# Altium schematic sheet Sway Power Switch Rev B - Sheet 1.SchDoc
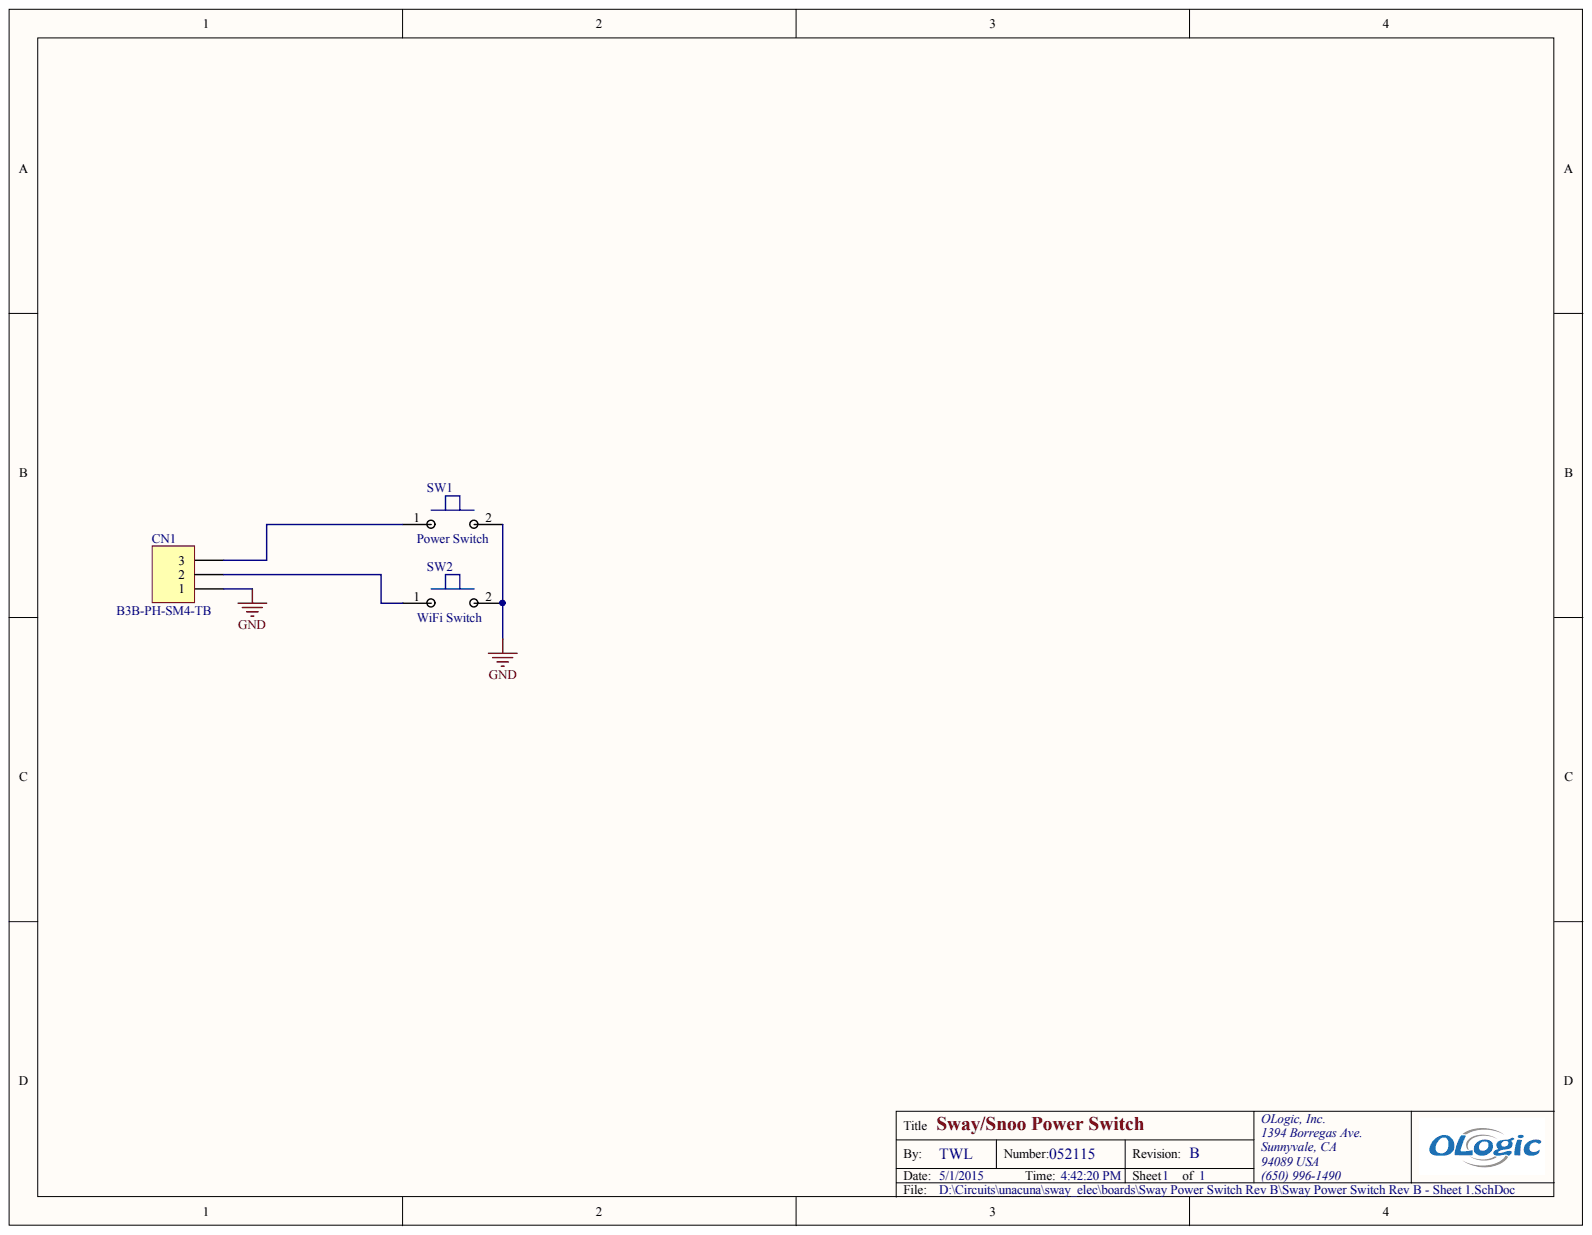
[diagram p0: Sway Power Switch Rev B - Sheet 1.SchDoc]

## Parts
CN1  B3B-PH-SM4-TB  [JST-B3B-PH-SM4-TB]
SW1  Power Switch  [TE-TactSwitch-3mmActuator]
SW2  WiFi Switch  [TE-TactSwitch-3mmActuator]

## Nets
GND: CN1.1 SW1.2 SW2.2
NetCN1_2: CN1.2 SW2.1
NetCN1_3: CN1.3 SW1.1

## Library data of the parts
CN1 = JST-B3B-PH-SM4-TB: CONN HEADER PH TOP 3POS 2MM SMD; Manufacturer: JST Sales America Inc; Manufacturer Part Number: B3B-PH-SM4-TB(LF)(SN)(P); Connector Type: Header, Shrouded; Number of Positions: 3; Number of Positions Loaded: All; Pitch: 0.079" (2.00mm); Category: Connectors, Interconnects; Color: Natural; Component Kind: Standard; ComponentLink1Description: http://www.jst-mfg.com/product/pdf/eng/ePH.pdf; ComponentLink1URL: http://www.jst-mfg.com/product/pdf/eng/ePH.pdf; Contact Finish: Tin; Contact Finish Thickness: -; Contact Mating Length: 0.130" (3.30mm); Contact Type: Male Pin; Fastening Type: Detent Lock; Features: Solder Retention; Library Name: OLogic-Connectors.IntLib; Mounting Type: Surface Mount; Number of Rows: 1; Packaging: Cut Tape (CT); Pricing 1: 1=0.6, 10=0.566, 25=0.5096, 50=0.4528, 100=0.434, 250=0.39628, 500=0.37742 (USD); RoHS: RoHS Compliant; Row Spacing: -; Stock 1: 32550; Supplier 1: Digi-Key; Supplier Part Number 1: 455-1735-1-ND; Termination: Solder
SW1 SW2 = SW-TE-FSM6JH: Reset Button; Manufacturer: TE Connectivity; Manufacturer Part Number: 1-1825910-0; Actuator Height off PCB, from Pins: 7.00mm; Actuator Material: Polyamide (PA66), Nylon 6/6; Actuator Orientation: Top Actuated; Actuator Type: Standard; Circuit: SPST-NO; Contact Rating @ Voltage: 0.05A @ 24VDC; Illumination: Non-Illuminated; Mechanical Life: 100,000 Cycles; Operating Force: 160gf; Outline: 6.00mm x 6.00mm; Switch Function: Off-Mom; Switch Travel: 0.25mm; Vendor: Digikey; Category: Switches; Component Kind: Standard; ComponentLink1Description: http://www.te.com/commerce/DocumentDelivery/DDEController?Action=srchrtrv&DocNm=1825910&DocType=Customer+Drawing&DocLang=English; ComponentLink1URL: http://www.te.com/commerce/DocumentDelivery/DDEController?Action=srchrtrv&DocNm=1825910&DocType=Customer+Drawing&DocLang=English; Features: -; Illumination Type, Color: -; Illumination Voltage (Nominal): -; Ingress Protection: -; Library Name: OLogic-Switches.IntLib; Mounting Type: Through Hole; Operating Temperature: -35°C ~ 85°C; Packaging: Bulk; Pricing 1: 1=0.12, 10=0.111, 25=0.1076, 50=0.1036, 100=0.0979, 250=0.09216, 500=0.08832, 1000=0.0672 (USD); RoHS: RoHS Compliant; Stock 1: 38756; Supplier 1: Digi-Key; Supplier Part Number 1: 450-1652-ND; Termination Style: PC Pin
